# Altium schematic sheet BMS_Current_Sense.SchDoc
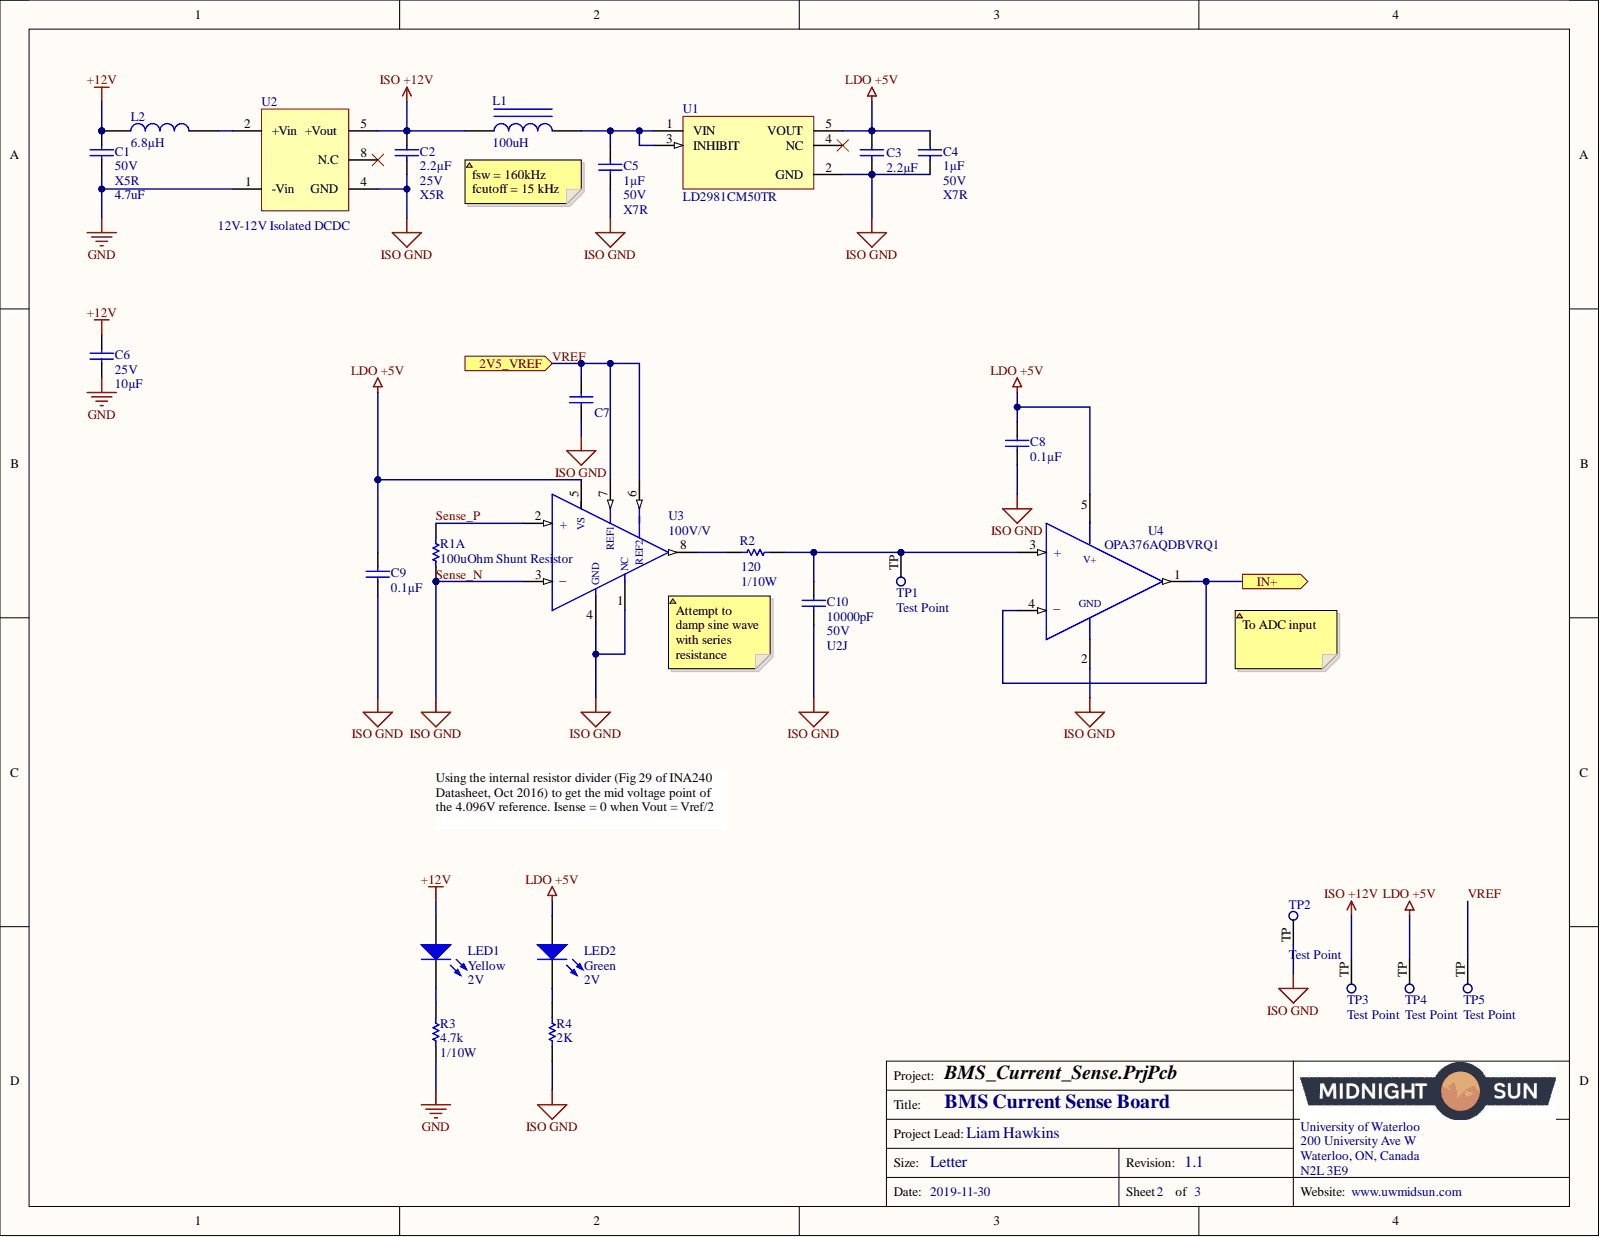
[diagram p0: BMS_Current_Sense.SchDoc]

## Parts
C1  value 4.7uF  Temperature Coefficient: X5R  Voltage - Rated: 50V  [CAP, 0805]  (hidden comment: CAP CER 4.7UF 50V 10% X5R 0805)
C2  Capacitance: 2.2µF  Temperature Coefficient: X5R  Voltage: 25V  [CAP, 0603]  (hidden comment: CAP CER 2.2UF 25V 10% X5R 0603)
C3  Capacitance: 2.2µF  [CAP, 0603]  (hidden comment: CAP CER 2.2UF 25V 10% X5R 0603)
C4  Capacitance: 1µF  Temperature Coefficient: X7R  Voltage: 50V  [CAP, 0603]  (hidden comment: CAP CER 1UF 50V 10% X7R 0603)
C5  Capacitance: 1µF  Temperature Coefficient: X7R  Voltage: 50V  [CAP, 0603]  (hidden comment: CAP CER 1UF 50V 10% X7R 0603)
C6  Capacitance: 10µF  Voltage - Rated: 25V  [CAP, 0805]  (hidden comment: CAP CER 10uF 25V 10% X5R 0805)
C7  CAP CER 0.022UF 50V 10% X7R 0603  [CAP, 0603]  (value not drawn)
C8  Capacitance: 0.1µF  [CAP, 0603]  (hidden comment: CAP CER 0.1UF 50V 10% X7R 0603)
C9  Capacitance: 0.1µF  [CAP, 0603]  (hidden comment: CAP CER 0.1UF 50V 10% X7R 0603)
C10  Capacitance: 10000pF  Temperature Coefficient: U2J  Voltage: 50V  [CAP, 0603]  (hidden comment: CAP CER 10nF 50V 5% X7R 0603)
L1  value 100uH  [1210]  (hidden comment: IND 100uH 60mA 5% 1210)
L2  Inductance: 6.8µH  [NLFV32T-6R8M-EF/IND 6.8uH]  (hidden comment: IND 6.8uH 260mA 20% 1210)
LED1  Color: Yellow  Voltage - Forward (Vf) (Typ): 2V  [LED_0603]  (hidden comment: LED YELLOW CLEAR 2.1V 0603)
LED2  Color: Green  Voltage - Forward (Vf) (Typ): 2V  [LED_0603]  (hidden comment: LED GREEN CLEAR 2V 0603)
R1  100uOhm Shunt Resistor  [RES SHUNT 100UOHM 60MM]  (part A)
R2  Power: 1/10W  [RES, 0603]  (hidden comment: RES 120 OHM 1% 1/10W 0603)
R3  Power (Watts): 1/10W  Resistance (Ohms): 4.7k  [RES, 0603]  (hidden comment: RES 4.7K OHM 1% 1/10W 0603)
R4  2K  [RES, 0603]
TP1  Test Point  [Test Point]  (no BOM)
TP2  Test Point  [Test Point]  (no BOM)
TP3  Test Point  [Test Point]  (no BOM)
TP4  Test Point  [Test Point]  (no BOM)
TP5  Test Point  [Test Point]  (no BOM)
U1  Manufacturer Part Number 1: LD2981CM50TR  [SOT95P280X145-5N]  (hidden comment: IC REG LDO 5V 0.1A SOT23-5)
U2  Type: 12V-12V Isolated DCDC  [IC, Isolated DCDC]  (hidden comment: IC DCDC ISOLATED 12V 1W 8-SMD 5-LEAD)
U3  100V/V  [IC CURRENT AMPLIFIER INA240 TSSOP-8]
U4  Manufacturer Part Number: OPA376AQDBVRQ1  [IC OP AMP GP RR 10MHZ SOT-23-5]  (hidden comment: IC OP AMP GEN PURPOSE RR 5.5MHZ SOT-23-5)

## Ports
2V5_VREF, IN+

## Nets
+12V: C1.1 C6.1 L2.1 LED1.1
GND: C1.2 C6.2 R3.2 U2.1(-Vin)
ISO +12V: C2.1 L1.1 TP3.TP U2.5(+Vout)
LDO +5V: C3.1 C4.1 C8.1 C9.1 LED2.1 TP4.TP U1.5(VOUT) U3.5(VS) U4.5
IN+: U4.1 U4.4 ; port IN+
Sense_2_P: R1.5 U3.2(+)  [also labelled Sense_P]
Sense_N: C2.2 C3.2 C4.2 C5.2 C7.2 C8.2 C9.2 C10.2 R1.6 R4.2 TP2.TP U1.2(GND) U2.4(GND) U3.1(NC) U3.3 U3.4(GND) U4.2
VREF: C7.1 TP5.TP U3.6 U3.7(REF1) ; port 2V5_VREF
NetC5_1: C5.1 L1.2 U1.1(VIN) U1.3(INHIBIT)
NetC10_1: C10.1 R2.2 TP1.TP U4.3(+)
NetL2_2: L2.2 U2.2(+Vin)
NetLED1_2: LED1.2 R3.1
NetLED2_2: LED2.2 R4.1
NetR2_1: R2.1 U3.8

Not connected: U1 4(NC); U2 8(N.C)

## Library data of the parts
C1 = CAP CER 4.7UF 50V 10% X5R 0805: 4.7µF ±20% 50V Ceramic Capacitor X5R 0805 (2012 Metric); Manufacturer 1: Murata Electronics North America; Manufacturer Part Number 1: GRT21BR61H475ME13L; Description 1: CAP CER 4.7UF 50V X5R 0805; Applications: Automotive; Category: Capacitors; Component Kind: Standard; ComponentLink1Description: http://search.murata.co.jp/Ceramy/image/img/A01X/G101/ENG/GRT21BR61H475ME13-01.pdf; ComponentLink1URL: http://search.murata.co.jp/Ceramy/image/img/A01X/G101/ENG/GRT21BR61H475ME13-01.pdf; Features: -; Height - Seated (Max): -; Lead Spacing: -; Lead Style: -; Mounting Type: Surface Mount, MLCC; Operating Temperature: -55°C ~ 85°C; Package / Case: 0805 (2012 Metric); Packaging: Cut Tape (CT); Part Status: Active; Pricing 1: 1=0.56, 10=0.389, 100=0.2338, 500=0.17536, 1000=0.14808 (CAD); Ratings: AEC-Q200; RoHS: RoHS Compliant; Size / Dimension: 0.079" L x 0.049" W (2.00mm x 1.25mm); Stock 1: 4286; Supplier 1: Digi-Key; Supplier Part Number 1: 490-12395-1-ND; Thickness (Max): 0.057" (1.45mm); Tolerance: ±20%; Library Name: Schematic Diagrams.SchLib
C2 C3 = CAP CER 2.2UF 25V 10% X5R 0603: 2.2µF ±10% 25V Ceramic Capacitor X5R 0603 (1608 Metric); Manufacturer 1: Murata Electronics North America; Manufacturer Part Number 1: GRM188R61E225KA12D; Component Kind: Standard; ComponentLink1Description: http://www.murata.com/~/media/webrenewal/support/library/catalog/products/capacitor/mlcc/c02e.ashx; ComponentLink1URL: http://www.murata.com/~/media/webrenewal/support/library/catalog/products/capacitor/mlcc/c02e.ashx; Height - Seated (Max): -; Lead Spacing: -; Lead Style: -; Mounting Type: Surface Mount, MLCC; Operating Temperature: -55°C ~ 85°C; Package / Case: 0603 (1608 Metric); Packaging: Cut Tape (CT); Part Status: Active; Ratings: -; Size / Dimension: 0.063" L x 0.031" W (1.60mm x 0.80mm); Supplier 1: Digi-Key; Supplier Part Number 1: 490-10731-1-ND; Thickness (Max): 0.035" (0.90mm); Tolerance: ±10%; Library Name: Schematic Diagrams.SchLib
  C3: Temperature Coefficient: X5R; Voltage: 25V
C4 C5 = CAP CER 1UF 50V 10% X7R 0603: 1µF ±10% 50V Ceramic Capacitor X7R 0603 (1608 Metric); Manufacturer 1: Taiyo Yuden; Manufacturer Part Number 1: UMK107AB7105KA-T; Component Kind: Standard; ComponentLink1Description: http://www.yuden.co.jp/productdata/catalog/en/mlcc_all_e.pdf; ComponentLink1URL: http://www.yuden.co.jp/productdata/catalog/en/mlcc_all_e.pdf; Height - Seated (Max): -; Lead Spacing: -; Lead Style: -; Mounting Type: Surface Mount, MLCC; Operating Temperature: -55°C ~ 125°C; Package / Case: 0603 (1608 Metric); Packaging: Cut Tape (CT); Part Status: Active; Ratings: -; Size / Dimension: 0.063" L x 0.031" W (1.60mm x 0.80mm); Supplier 1: Digi-Key; Supplier Part Number 1: 587-3247-1-ND; Thickness (Max): 0.037" (0.95mm); Tolerance: ±10%; Library Name: Schematic Diagrams.SchLib
C6 = CAP CER 10uF 25V 10% X5R 0805: 10µF ±10% 25V Ceramic Capacitor X5R 0805 (2012 Metric); Manufacturer: Murata Electronics North America; Manufacturer Part Number: GRM21BR61E106KA73L; Applications: General Purpose; Category: Capacitors; Component Kind: Standard; ComponentLink1Description: http://search.murata.co.jp/Ceramy/image/img/A01X/G101/ENG/GRM21BR61E106KA73-01.pdf; ComponentLink1URL: http://search.murata.co.jp/Ceramy/image/img/A01X/G101/ENG/GRM21BR61E106KA73-01.pdf; Features: -; Height - Seated (Max): -; Lead Spacing: -; Lead Style: -; Mounting Type: Surface Mount, MLCC; Operating Temperature: -55°C ~ 85°C; Package / Case: 0805 (2012 Metric); Packaging: Cut Tape (CT); Part Status: Active; Pricing 1: 1=0.3, 10=0.214, 100=0.1008, 500=0.07068, 1000=0.05851 (CAD); Ratings: -; RoHS: RoHS Compliant; Size / Dimension: 0.079" L x 0.049" W (2.00mm x 1.25mm); Stock 1: 1695157; Supplier 1: Digi-Key; Supplier Part Number 1: 490-5523-1-ND; Temperature Coefficient: X5R; Thickness (Max): 0.053" (1.35mm); Tolerance: ±10%; Library Name: Schematic Diagrams.SchLib
C7 = CAP CER 0.022UF 50V 10% X7R 0603: 0.022µF ±10% 50V Ceramic Capacitor X7R 0603 (1608 Metric); Manufacturer 1: Murata Electronics North America; Manufacturer Part Number 1: GRM188R71H223KA01D; Description 1: CAP CER 0.022UF 50V X7R 0603; Applications: General Purpose; Category: Capacitors; Component Kind: Standard; ComponentLink1Description: http://search.murata.co.jp/Ceramy/image/img/A01X/G101/ENG/GRM188R71H223KA01-01.pdf; ComponentLink1URL: http://search.murata.co.jp/Ceramy/image/img/A01X/G101/ENG/GRM188R71H223KA01-01.pdf; Features: -; Height - Seated (Max): -; Lead Spacing: -; Lead Style: -; Mounting Type: Surface Mount, MLCC; Operating Temperature: -55°C ~ 125°C; Package / Case: 0603 (1608 Metric); Packaging: Cut Tape (CT); Part Status: Active; Pricing 1: 1=0.15, 10=0.111, 100=0.0499, 500=0.03564, 1000=0.02801 (CAD); Ratings: -; RoHS: RoHS Compliant; Size / Dimension: 0.063" L x 0.032" W (1.60mm x 0.80mm); Stock 1: 612330; Supplier 1: Digi-Key; Supplier Part Number 1: 490-1517-1-ND; Temperature Coefficient: X7R; Thickness (Max): 0.035" (0.90mm); Tolerance: ±10%; Voltage - Rated: 50V; Library Name: Schematic Diagrams.SchLib
C8 C9 = CAP CER 0.1UF 50V 10% X7R 0603: 0.10µF ±10% 50V Ceramic Capacitor X7R 0603 (1608 Metric); Manufacturer 1: AVX Corporation; Manufacturer Part Number 1: 06035C104KAT2A; Component Kind: Standard; ComponentLink1Description: http://datasheets.avx.com/X7RDielectric.pdf; ComponentLink1URL: http://datasheets.avx.com/X7RDielectric.pdf; Height - Seated (Max): -; Lead Spacing: -; Lead Style: -; Mounting Type: Surface Mount, MLCC; Operating Temperature: -55°C ~ 125°C; Package / Case: 0603 (1608 Metric); Packaging: Cut Tape (CT); Part Status: Active; Ratings: -; Size / Dimension: 0.063" L x 0.032" W (1.60mm x 0.81mm); Supplier 1: Digi-Key; Supplier Part Number 1: 478-5052-1-ND; Temperature Coefficient: X7R; Thickness (Max): 0.035" (0.90mm); Tolerance: ±10%; Voltage: 50V; Library Name: Schematic Diagrams.SchLib
C10 = CAP CER 10nF 50V 5% X7R 0603: 10000pF ±5% 50V Ceramic Capacitor U2J 0603 (1608 Metric); Manufacturer 1: KEMET; Manufacturer Part Number 1: C0603C103J5JAC7867; Component Kind: Standard; ComponentLink1Description: http://www.kemet.com/docfinder?Partnumber=C0603C103J5JAC7867; ComponentLink1URL: http://www.kemet.com/docfinder?Partnumber=C0603C103J5JAC7867; Failure Rate: -; Height - Seated (Max): -; Lead Spacing: -; Lead Style: -; Mounting Type: Surface Mount, MLCC; Operating Temperature: -55°C ~ 125°C; Package / Case: 0603 (1608 Metric); Packaging: Cut Tape (CT); Part Status: Active; Ratings: -; Size / Dimension: 0.063" L x 0.032" W (1.60mm x 0.80mm); Supplier 1: Digi-Key; Supplier Part Number 1: 399-13384-1-ND; Thickness (Max): 0.034" (0.87mm); Tolerance: ±5%; Library Name: Schematic Diagrams.SchLib
L1 = IND 100uH 60mA 5% 1210: 100µH Unshielded Wirewound Inductor 60mA 11 Ohm Max 1210 (3225 Metric); Manufacturer: EPCOS (TDK); Manufacturer Part Number: B82422T1104J000; Material - Core: Ferrite; Q @ Freq: 20 @ 796kHz; Category: Inductors, Coils, Chokes; Component Kind: Standard; ComponentLink1Description: https://en.tdk.eu/inf/30/db/ind_2008/b82422t.pdf; ComponentLink1URL: https://en.tdk.eu/inf/30/db/ind_2008/b82422t.pdf; DC Resistance (DCR): 11 Ohm Max; Height - Seated (Max): 0.083" (2.10mm); Mounting Type: Surface Mount; Operating Temperature: -55°C ~ 125°C; Package / Case: 1210 (3225 Metric); Packaging: Cut Tape (CT); Part Status: Active; Pricing 1: 1=0.65, 10=0.568, 100=0.4062, 500=0.3412, 1000=0.27621 (CAD); Ratings: AEC-Q200; RoHS: RoHS Compliant; Shielding: Unshielded; Size / Dimension: 0.126" L x 0.098" W (3.20mm x 2.50mm); Stock 1: 4846; Supplier 1: Digi-Key; Supplier Device Package: 1210 (3225 Metric); Supplier Part Number 1: 495-5646-1-ND; Tolerance: ±5%; Type: Wirewound; Library Name: Schematic Diagrams.SchLib
L2 = IND 6.8uH 260mA 20% 1210: 6.8µH Shielded Wirewound Inductor 260mA 204 mOhm Max 1210 (3225 Metric); Manufacturer 1: TDK Corporation; Manufacturer Part Number 1: NLFV32T-6R8M-EF; Material - Core: Ferrite; Component Kind: Standard; ComponentLink1Description: https://product.tdk.com/info/en/catalog/datasheets/inductor_commercial_decoupling_nlfv32-ef_en.pdf; ComponentLink1URL: https://product.tdk.com/info/en/catalog/datasheets/inductor_commercial_decoupling_nlfv32-ef_en.pdf; Current - Saturation: -; Current Rating: 260mA; DC Resistance (DCR): 204 mOhm Max; Frequency - Self Resonant: -; Height - Seated (Max): 0.094" (2.40mm); Mounting Type: Surface Mount; Operating Temperature: -40°C ~ 105°C; Package / Case: 1210 (3225 Metric); Packaging: Cut Tape (CT); Part Status: Active; Q @ Freq: -; Ratings: -; Shielding: Shielded; Size / Dimension: 0.126" L x 0.098" W (3.20mm x 2.50mm); Supplier 1: Digi-Key; Supplier Device Package: 1210 (3225 Metric); Supplier Part Number 1: 445-15776-1-ND; Tolerance: ±20%; Type: Wirewound; Library Name: Schematic Diagrams.SchLib
LED1 = LED YELLOW CLEAR 2.1V 0603: Yellow 590nm LED Indication - Discrete 2V 0603 (1608 Metric); Manufacturer: Wurth Electronics Inc.; Manufacturer Part Number: 150060YS75000; Current - Test: 20mA; Lens Color: Colorless; Lens Style/Size: Rectangle with Flat Top, 1.10mm x 0.70mm; Millicandela Rating: 120mcd; Viewing Angle: 140°; Wavelength - Peak: 595nm; Category: Optoelectronics; Component Kind: Standard; ComponentLink1Description: http://katalog.we-online.de/led/datasheet/150060YS75000.pdf; ComponentLink1URL: http://katalog.we-online.de/led/datasheet/150060YS75000.pdf; Features: -; Height (Max): 0.80mm; Lens Transparency: Clear; Mounting Type: Surface Mount; Package / Case: 0603 (1608 Metric); Packaging: Cut Tape (CT); Part Status: Active; Pricing 1: 1=0.21, 100=0.1617, 500=0.13964 (CAD); RoHS: RoHS Compliant; Size / Dimension: 1.60mm L x 0.80mm W; Stock 1: 10523; Supplier 1: Digi-Key; Supplier Device Package: 0603; Supplier Part Number 1: 732-4981-1-ND; Wavelength - Dominant: 590nm; Library Name: Schematic Diagrams.SchLib
LED2 = LED GREEN CLEAR 2V 0603: Green 572nm LED Indication - Discrete 2V 0603 (1608 Metric); Manufacturer: Wurth Electronics Inc.; Manufacturer Part Number: 150060VS75000; Current - Test: 20mA; Lens Color: Colorless; Lens Style/Size: Rectangle with Flat Top, 1.10mm x 0.70mm; Millicandela Rating: 40mcd; Viewing Angle: 140°; Wavelength - Dominant: 570nm; Category: Optoelectronics; Component Kind: Standard; ComponentLink1Description: http://katalog.we-online.de/led/datasheet/150060VS75000.pdf; ComponentLink1URL: http://katalog.we-online.de/led/datasheet/150060VS75000.pdf; Features: -; Height (Max): 0.80mm; Lens Transparency: Clear; Mounting Type: Surface Mount; Package / Case: 0603 (1608 Metric); Packaging: Cut Tape (CT); Part Status: Active; Pricing 1: 1=0.21, 100=0.1617, 500=0.13964 (CAD); RoHS: RoHS Compliant; Size / Dimension: 1.60mm L x 0.80mm W; Stock 1: 9408; Supplier 1: Digi-Key; Supplier Device Package: 0603; Supplier Part Number 1: 732-4980-1-ND; Wavelength - Peak: 572nm; Library Name: Schematic Diagrams.SchLib
R1 = RES SHUNT 100UOHM 5% 36W 60MM: 100 µOhms ±5% 36W Shunt, Battery Specialized Resistor Metal Element ±175ppm/°C Shunt Fixture, 60mm Pitch; Manufacturer 1: Vishay Dale; Manufacturer Part Number 1: WSBM8518L1000JK; Applications: -; Category: Resistors; Component Kind: Standard; ComponentLink1Description: http://www.vishay.com/docs/31094/wsbm8518.pdf; ComponentLink1URL: http://www.vishay.com/docs/31094/wsbm8518.pdf; Composition: Metal Element; Description 1: RES SHUNT 100 UOHM 5% 36W; Mounting Type: Shunt Fixture, 60mm Pitch; Operating Temperature: -65°C ~ 170°C; Packaging: Bulk; Part Status: Active; Power (Watts): 36W; Pricing 1: 1=16.24, 10=14.764, 25=13.2876, 50=11.442, 100=10.5192, 250=9.9656, 500=9.5965, 1000=9.15359 (CAD); RoHS: RoHS Compliant; Stock 1: 0; Supplier 1: Digi-Key; Supplier Part Number 1: 541-1906-ND; Temperature Coefficient: ±175ppm/°C; Tolerance: ±5%; Type: Shunt, Battery; Library Name: Schematic Diagrams.SchLib
R2 = RES 120 OHM 1% 1/10W 0603: RES SMD 120 OHM 1% 1/10W 0603; Manufacturer 1: Yageo; Manufacturer Part Number 1: RC0603FR-07120RL; Resistance: 120; Temperature Coefficient: ±100ppm/°C; Component Kind: Standard; ComponentLink1Description: http://www.yageo.com/documents/recent/PYu-RC_Group_51_RoHS_L_7.pdf; ComponentLink1URL: http://www.yageo.com/documents/recent/PYu-RC_Group_51_RoHS_L_7.pdf; Composition: Thick Film; Failure Rate: -; Height - Seated (Max): 0.022" (0.55mm); Operating Temperature: -55°C ~ 155°C; Package / Case: 0603 (1608 Metric); Packaging: Cut Tape (CT); Part Status: Active; Size / Dimension: 0.063" L x 0.031" W (1.60mm x 0.80mm); Supplier 1: Digi-Key; Supplier Device Package: 0603; Supplier Part Number 1: 311-120HRCT-ND; Tolerance: ±1%; Library Name: Schematic Diagrams.SchLib
R3 = RES 4.7K OHM 1% 1/10W 0603: 4.7k Ohm ±1% 0.1W, 1/10W Chip Resistor 0603 (1608 Metric) Moisture Resistant Thick Film; Manufacturer 1: Yageo; Manufacturer Part Number 1: RC0603FR-074K7L; Description 1: RES SMD 4.7K OHM 1% 1/10W 0603; Temperature Coefficient: ±100ppm/°C; Category: Resistors; Component Kind: Standard; ComponentLink1Description: http://www.yageo.com/documents/recent/PYu-RC_Group_51_RoHS_L_7.pdf; ComponentLink1URL: http://www.yageo.com/documents/recent/PYu-RC_Group_51_RoHS_L_7.pdf; Composition: Thick Film; Failure Rate: -; Features: Moisture Resistant; Height - Seated (Max): 0.022" (0.55mm); Operating Temperature: -55°C ~ 155°C; Package / Case: 0603 (1608 Metric); Packaging: Cut Tape (CT); Part Status: Active; Pricing 1: 1=0.16, 10=0.022, 100=0.0089, 1000=0.00402, 2500=0.00349 (CAD); RoHS: RoHS Compliant; Size / Dimension: 0.063" L x 0.031" W (1.60mm x 0.80mm); Stock 1: 3487566; Supplier 1: Digi-Key; Supplier Device Package: 0603; Supplier Part Number 1: 311-4.70KHRCT-ND; Tolerance: ±1%; Library Name: Schematic Diagrams.SchLib
R4 = RES 2K OHM 1% 1/10W 0603: 2k Ohm ±1% 0.1W, 1/10W Chip Resistor 0603 (1608 Metric) Moisture Resistant Thick Film; Manufacturer: Yageo; Manufacturer Part Number: RC0603FR-072KL; Temperature Coefficient: ±100ppm/°C; Category: Resistors; Component Kind: Standard; ComponentLink1Description: http://www.yageo.com/documents/recent/PYu-RC_Group_51_RoHS_L_7.pdf; ComponentLink1URL: http://www.yageo.com/documents/recent/PYu-RC_Group_51_RoHS_L_7.pdf; Composition: Thick Film; Failure Rate: -; Features: Moisture Resistant; Height - Seated (Max): 0.022" (0.55mm); Operating Temperature: -55°C ~ 155°C; Package / Case: 0603 (1608 Metric); Packaging: Cut Tape (CT); Part Status: Active; Power (Watts): 0.1W, 1/10W; Pricing 1: 1=0.15, 10=0.023, 100=0.0093, 1000=0.00415, 2500=0.0036 (CAD); Resistance (Ohms): 2k; RoHS: RoHS Compliant; Size / Dimension: 0.063" L x 0.031" W (1.60mm x 0.80mm); Stock 1: 1750701; Supplier 1: Digi-Key; Supplier Device Package: 0603; Supplier Part Number 1: 311-2.00KHRCT-ND; Tolerance: ±1%; Library Name: Schematic Diagrams.SchLib
U1 = IC REG LDO 5V 0.1A SOT23-5: Linear Voltage Regulator IC Positive Fixed Output 5V 100mA SOT-23-5; Manufacturer 1: STMicroelectronics; Voltage - Dropout (Typical): 0.18V @ 100mA; Voltage - Input: Up to 16V; Component Kind: Standard; ComponentLink1Description: http://www.st.com/content/ccc/resource/technical/document/datasheet/group1/b7/b5/a1/83/9c/bd/4a/90/CD00001635/files/CD00001635.pdf/jcr:content/translations/en.CD00001635.pdf; ComponentLink1URL: http://www.st.com/content/ccc/resource/technical/document/datasheet/group1/b7/b5/a1/83/9c/bd/4a/90/CD00001635/files/CD00001635.pdf/jcr:content/translations/en.CD00001635.pdf; Current - Limit (Min): -; Current - Output: 100mA; Mounting Type: Surface Mount; Operating Temperature: -40°C ~ 125°C; Package / Case: SC-74A, SOT-753; Packaging: Cut Tape (CT); Part Status: Active; Regulator Topology: Positive Fixed; Supplier 1: Digi-Key; Supplier Device Package: SOT-23-5; Supplier Part Number 1: 497-7787-1-ND; Voltage - Output: 5V; Library Name: Schematic Diagrams.SchLib
U2 = IC DCDC ISOLATED 12V 1W 8-SMD 5-LEAD: Isolated Module DC DC Converter 1 Output 12V 84mA 10.8V - 13.2V Input; Manufacturer 1: XP Power; Manufacturer Part Number 1: ISE1212A; Efficiency: 81%; Number of Outputs: 1; Voltage - Isolation: 1.5kV (1500V); Component Kind: Standard; ComponentLink1Description: http://www.xppower.com/Portals/0/pdfs/SF_ISE.pdf; ComponentLink1URL: http://www.xppower.com/Portals/0/pdfs/SF_ISE.pdf; Current - Output (Max): 84mA; Mounting Type: Surface Mount; Operating Temperature: -40°C ~ 105°C; Package / Case: 8-SMD Module, 5 Leads; Packaging: Cut Tape (CT); Part Status: Active; Power: 1W; Size / Dimension: 0.50" L x 0.44" W x 0.29" H (12.7mm x 11.2mm x 7.3mm); Supplier 1: Digi-Key; Supplier Part Number 1: 1470-2950-1-ND; Voltage - Input (Max): 13.2V; Voltage - Input (Min): 10.8V; Voltage - Output 1: 12V; Voltage - Output 2: -; Voltage - Output 3: -; Library Name: Schematic Diagrams.SchLib
U3 = IC CURRENT AMPLIFIER INA240 8-TSSOP: Current Sense Amplifier 1 Circuit 8-TSSOP; Manufacturer 1: Texas Instruments; Manufacturer Part Number 1: INA240A3PWR; Current - Supply: 1.8mA; Description 1: IC AMP CURRENT SENSE 8-TSSOP; Slew Rate: 2 V/µs; Voltage - Supply, Single/Dual (±): 2.7 V ~ 5.5 V; Amplifier Type: Current Sense; Category: Integrated Circuits (ICs); Component Kind: Standard; ComponentLink1Description: http://www.ti.com/lit/ds/symlink/ina240.pdf; ComponentLink1URL: http://www.ti.com/lit/ds/symlink/ina240.pdf; Mounting Type: Surface Mount; Operating Temperature: -40°C ~ 125°C; Output Type: -; Package / Case: 8-TSSOP (0.173", 4.40mm Width); Packaging: Cut Tape (CT); Part Status: Active; Pricing 1: 1=3.79, 10=3.397, 100=2.7311, 500=2.24388, 1000=1.85921 (CAD); RoHS: RoHS Compliant; Stock 1: 1286; Supplier 1: Digi-Key; Supplier Device Package: 8-TSSOP; Supplier Part Number 1: 296-45090-1-ND; Library Name: Schematic Diagrams.SchLib
U4 = IC OP AMP GEN PURPOSE RR 5.5MHZ SOT-23-5: General Purpose Amplifier 1 Circuit Rail-to-Rail SOT-23-5; Manufacturer: Texas Instruments; Common Mode Rejection Ratio: 76dB; Input Bias Current: 10pA; Input Offset Voltage (Vos): 25uV; Max Supply Voltage: 5.5V; Min Supply Voltage: 2.2V; Nominal Supply Current: 950uA; Number of Channels: 1; Operating Supply Current: 760uA; Output Current: 30mA; Output Current per Channel: 50mA; Power Supply Rejection Ratio (PSRR): 106.02dB; Slew Rate: 2V/µs; Voltage Gain: 134dB; Case/Package: SOT-23-5; Component Kind: Standard; ComponentLink1Description: http://www.ti.com/lit/ds/sbos549/sbos549.pdf?src-supplier=Avnet; ComponentLink1URL: http://www.ti.com/lit/ds/sbos549/sbos549.pdf?src-supplier=Avnet; Gain Bandwidth Product: 5.5MHz; Lead Free: Lead Free; Max I/O Voltage: 25uV; Max Operating Temperature: 125°C; Min Operating Temperature: -40°C; Number of Circuits: 1; Number of Elements: 1; Number of Pins: 5; Package Quantity: 3000; Packaging: Tape and Reel; Pricing 1: 1 = 2.14000, 10 = 1.92200, 100 = 1.54450, 500 = 1.26896, 1000 = 1.05142 (USD); Radiation Hardening: No; RoHS: Yes; Stock 1: 26635; Supplier 1: Digi-Key; Supplier Part Number 1: 296-36701-1-ND; Unity Gain Bandwidth Product: 5.5MHz; Library Name: Schematic Diagrams.SchLib
TP1-TP5 = Test Point: Library Name: Schematic Diagrams.SchLib
